# Altium schematic sheet Akvo 36K schimatics.SchDoc
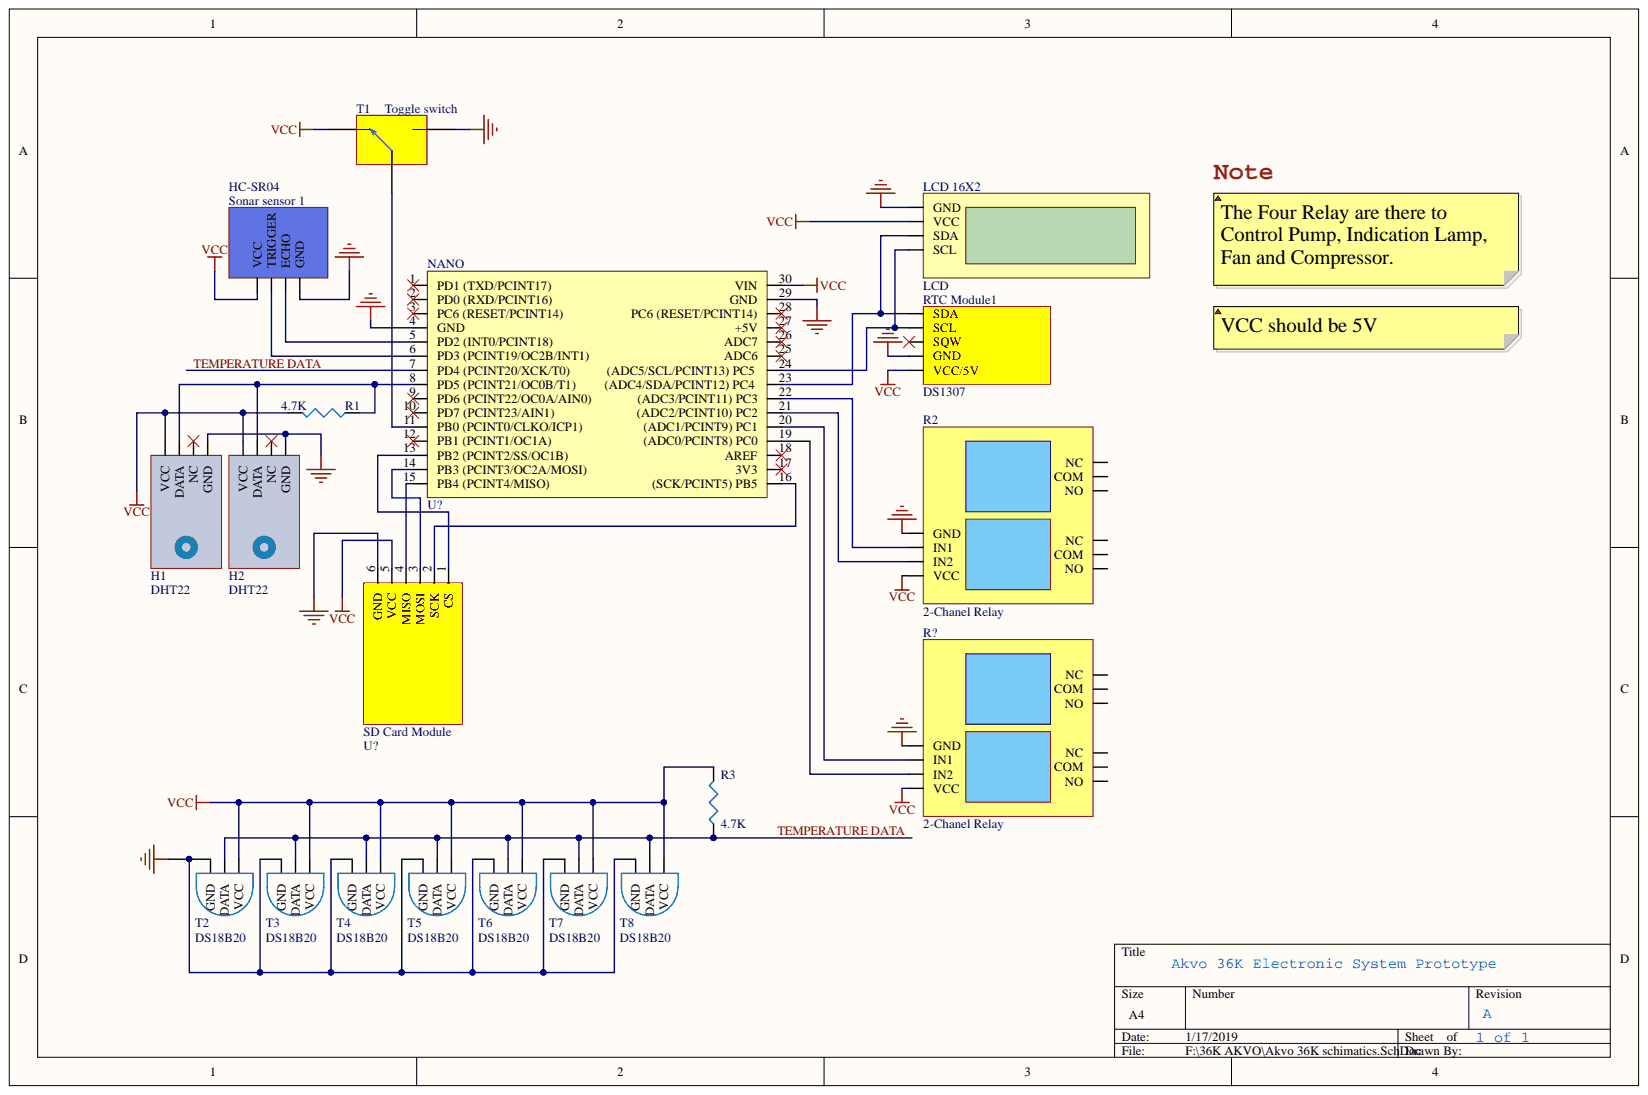
[diagram p0: Akvo 36K schimatics.SchDoc]

## Parts
H1  DHT22
H2  DHT22
LCD_16X2  LCD
NANO  U?
R1  4.7K
R2  2-Chanel Relay
R3  4.7K
R?  2-Chanel Relay
RTC_Module1  DS1307
SD_Card_Module  U?
Sonar_sensor_1  HC-SR04
T1  Toggle switch
T2  DS18B20
T3  DS18B20
T4  DS18B20
T5  DS18B20
T6  DS18B20
T7  DS18B20
T8  DS18B20

## Nets
GND: H1.4(GND) H2.4(GND) LCD_16X2.1(GND) NANO.4(GND) NANO.29(GND) R2.1(GND) R?.1(GND) RTC_Module1.4(GND) SD_Card_Module.6(GND) Sonar_sensor_1.1(GND) T1.3 T2.1 T3.1 T4.1 T5.1 T6.1 T7.1 T8.1
VCC: H1.1(VCC) H2.1(VCC) LCD_16X2.2(VCC) NANO.30(VIN) R1.2 R2.4(VCC) R3.2 R?.4(VCC) RTC_Module1.5(VCC/5V) SD_Card_Module.5(VCC) Sonar_sensor_1.4(VCC) T1.1 T2.3 T3.3 T4.3 T5.3 T6.3 T7.3 T8.3
TEMPERATURE DATA: NANO.7(PD4_(PCINT20/XCK/T0)) R3.1 T2.2 T3.2 T4.2 T5.2 T6.2 T7.2 T8.2
NetH1_2: H1.2(DATA) H2.2(DATA) NANO.8(PD5_(PCINT21/OC0B/T1)) R1.1
NetLCD 16X2_3: LCD_16X2.3(SDA) NANO.23((ADC4/SDA/PCINT12)_PC4) RTC_Module1.1(SDA)
NetLCD 16X2_4: LCD_16X2.4(SCL) NANO.24((ADC5/SCL/PCINT13)_PC5) RTC_Module1.2(SCL)
NetNANO_5: NANO.5(PD2_(INT0/PCINT18)) Sonar_sensor_1.2(ECHO)
NetNANO_6: NANO.6(PD3_(PCINT19/OC2B/INT1)) Sonar_sensor_1.3(TRIGGER)
NetNANO_11: NANO.11(PB0_(PCINT0/CLKO/ICP1)) T1.2
NetNANO_13: NANO.13(PB2_(PCINT2/SS/OC1B)) SD_Card_Module.1(CS)
NetNANO_14: NANO.14(PB3_(PCINT3/OC2A/MOSI)) SD_Card_Module.3(MOSI)
NetNANO_15: NANO.15(PB4_(PCINT4/MISO)) SD_Card_Module.4(MISO)
NetNANO_16: NANO.16((SCK/PCINT5)_PB5) SD_Card_Module.2(SCK)
NetNANO_19: NANO.19((ADC0/PCINT8)_PC0) R?.3(IN2)
NetNANO_20: NANO.20((ADC1/PCINT9)_PC1) R?.2(IN1)
NetNANO_21: NANO.21((ADC2/PCINT10)_PC2) R2.3(IN2)
NetNANO_22: NANO.22((ADC3/PCINT11)_PC3) R2.2(IN1)

Not connected: H1 3(NC); H2 3(NC); NANO 1(PD1_(TXD/PCINT17)) 2(PD0_(RXD/PCINT16)) 3(PC6_(RESET/PCINT14)) 9(PD6_(PCINT22/OC0A/AIN0)) 10(PD7_(PCINT23/AIN1)) 12(PB1_(PCINT1/OC1A)) 17(3V3) 18(AREF) 25(ADC6) 26(ADC7) 27(+5V) 28(PC6_(RESET/PCINT14)); R2 5(NC) 6(COM) 7(NO) 8(NC) 9(COM) 10(NO); R? 5(NC) 6(COM) 7(NO) 8(NC) 9(COM) 10(NO); RTC_Module1 3(SQW)

## Library data of the parts
LCD_16X2 = LCD 16X2: Component Kind: Standard; Library Name: Schlib1.SchLib
NANO = NANO ?: Component Kind: Standard; Library Name: Schlib1.SchLib
R1 R3 = FKN-25FR-52-4R7: RES 4.7 OHM 1/4W 1% AXIAL; Manufacturer: Yageo; Power (Watts): 0.25W, 1/4W; Resistance (Ohms): 4.7; Temperature Coefficient: ±350ppm/°C; Category: Resistors; Component Kind: Standard; ComponentLink1Description: //media.digikey.com/pdf/Data%20Sheets/Yageo%20PDFs/FKN_2011_DS.pdf; ComponentLink1URL: //media.digikey.com/pdf/Data%20Sheets/Yageo%20PDFs/FKN_2011_DS.pdf; Composition: Wirewound; Family: Through Hole Resistors; Features: Flame Proof, Fusible, Safety; Library Name: Schlib1.SchLib; Manufacturer Part Number: FKN-25FR-52-4R7; Number of Terminations: 2; Operating Temperature: -40°C ~ 155°C; Package / Case: Axial; Packaging: Tape & Reel (TR); Series: FKN; Size / Dimension: 0.094" Dia x 0.248" L (2.40mm x 6.30mm); Standard Package: 5,000; Stock 1: 0; Supplier 1: Digi-Key; Supplier Device Package: Axial; Supplier Part Number 1: FKN-25FR-52-4R7-ND; Tolerance: ±1%
RTC_Module1 = RTC Module: Component Kind: Standard; Library Name: Schlib1.SchLib
SD_Card_Module = SD Card Module ?: Component Kind: Standard; Library Name: Schlib1.SchLib
Sonar_sensor_1 = Sonar Sensor: Component Kind: Standard; Library Name: Schlib1.SchLib
H1 H2 = DHT22: Component Kind: Standard; Library Name: Schlib1.SchLib
R2 R? = 2-Chanel Relay: Component Kind: Standard; Library Name: Schlib1.SchLib
T1 = Toggle switch: Component Kind: Standard; Library Name: Schlib1.SchLib
T2-T8 = DS18B20: Component Kind: Standard; Library Name: Schlib1.SchLib
